# Altium schematic sheet Connectors.SchDoc(Connectors)
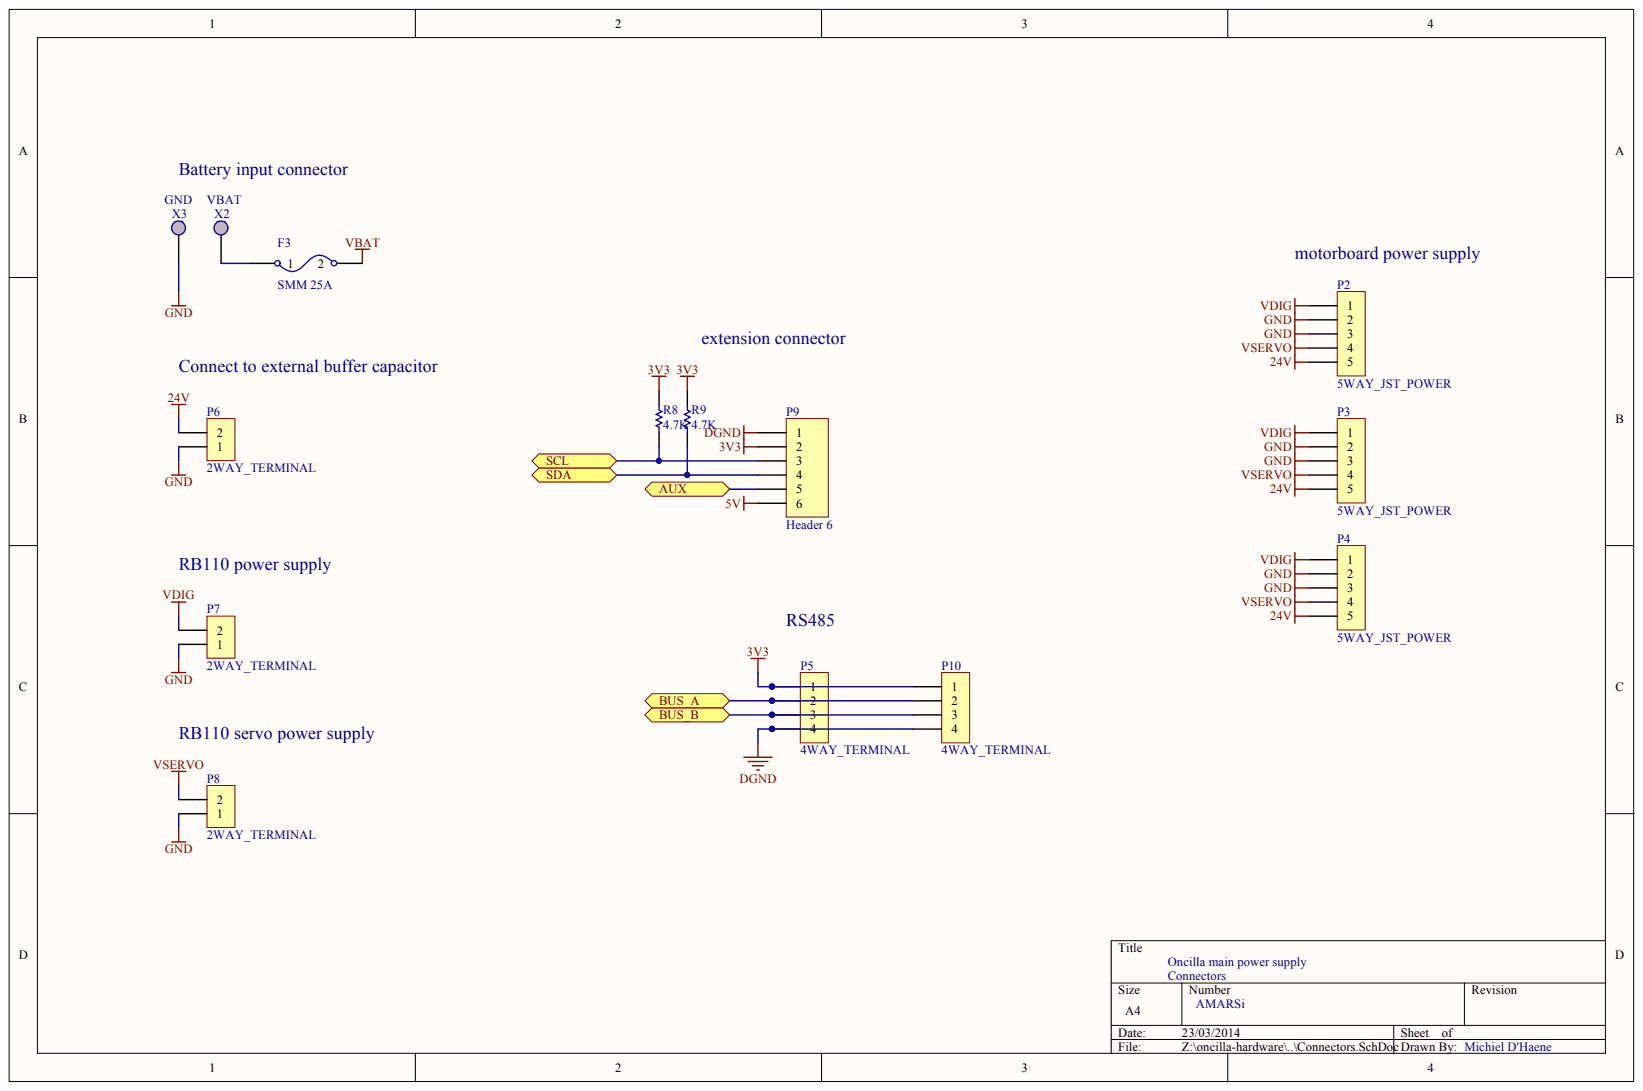
[diagram p0: Connectors.SchDoc]

## Parts
F3  SMM 25A
P2  5WAY_JST_POWER
P3  5WAY_JST_POWER
P4  5WAY_JST_POWER
P5  4WAY_TERMINAL
P6  2WAY_TERMINAL
P7  2WAY_TERMINAL
P8  2WAY_TERMINAL
P9  Header 6
P10  4WAY_TERMINAL
R8  4.7k
R9  4.7k
X2  VBAT
X3  GND

## Ports
AUX, BUS_A, BUS_B, SCL, SDA

## Nets
3V3: P5.1 P9.2 P10.1 R8.2 R9.2
5V: P9.6
24V: P2.5 P3.5 P4.5 P6.2
DGND: P5.4 P9.1 P10.4
GND: P2.2 P2.3 P3.2 P3.3 P4.2 P4.3 P6.1 P7.1 P8.1 X3.1
VBAT: F3.2
VDIG: P2.1 P3.1 P4.1 P7.2
VSERVO: P2.4 P3.4 P4.4 P8.2
AUX: P9.5 ; port AUX
BUS_A: P5.2 P10.2 ; port BUS_A
BUS_B: P5.3 P10.3 ; port BUS_B
SCL: P9.3 R8.1 ; port SCL
SDA: P9.4 R9.1 ; port SDA
NetF3_1: F3.1 X2.1

## Library data of the parts
F3 P2-P10 R8 R9 X2 X3 = (no symbol name)
  P9: LatestRevisionDate: 17-Jul-2002; LatestRevisionNote: Re-released for DXP Platform.
  R8 R9: Published: 8-Jun-2000; LatestRevisionDate: 17-Jul-2002; LatestRevisionNote: Re-released for DXP Platform.; PackageReference: AXIAL-0.5; Value: 4.7K
  P9 R8 R9: Publisher: Altium Limited
